# Altium schematic sheet LIDO-HW.100.RearConnector.SchDoc(LIDO-HW.100.RearConnector)
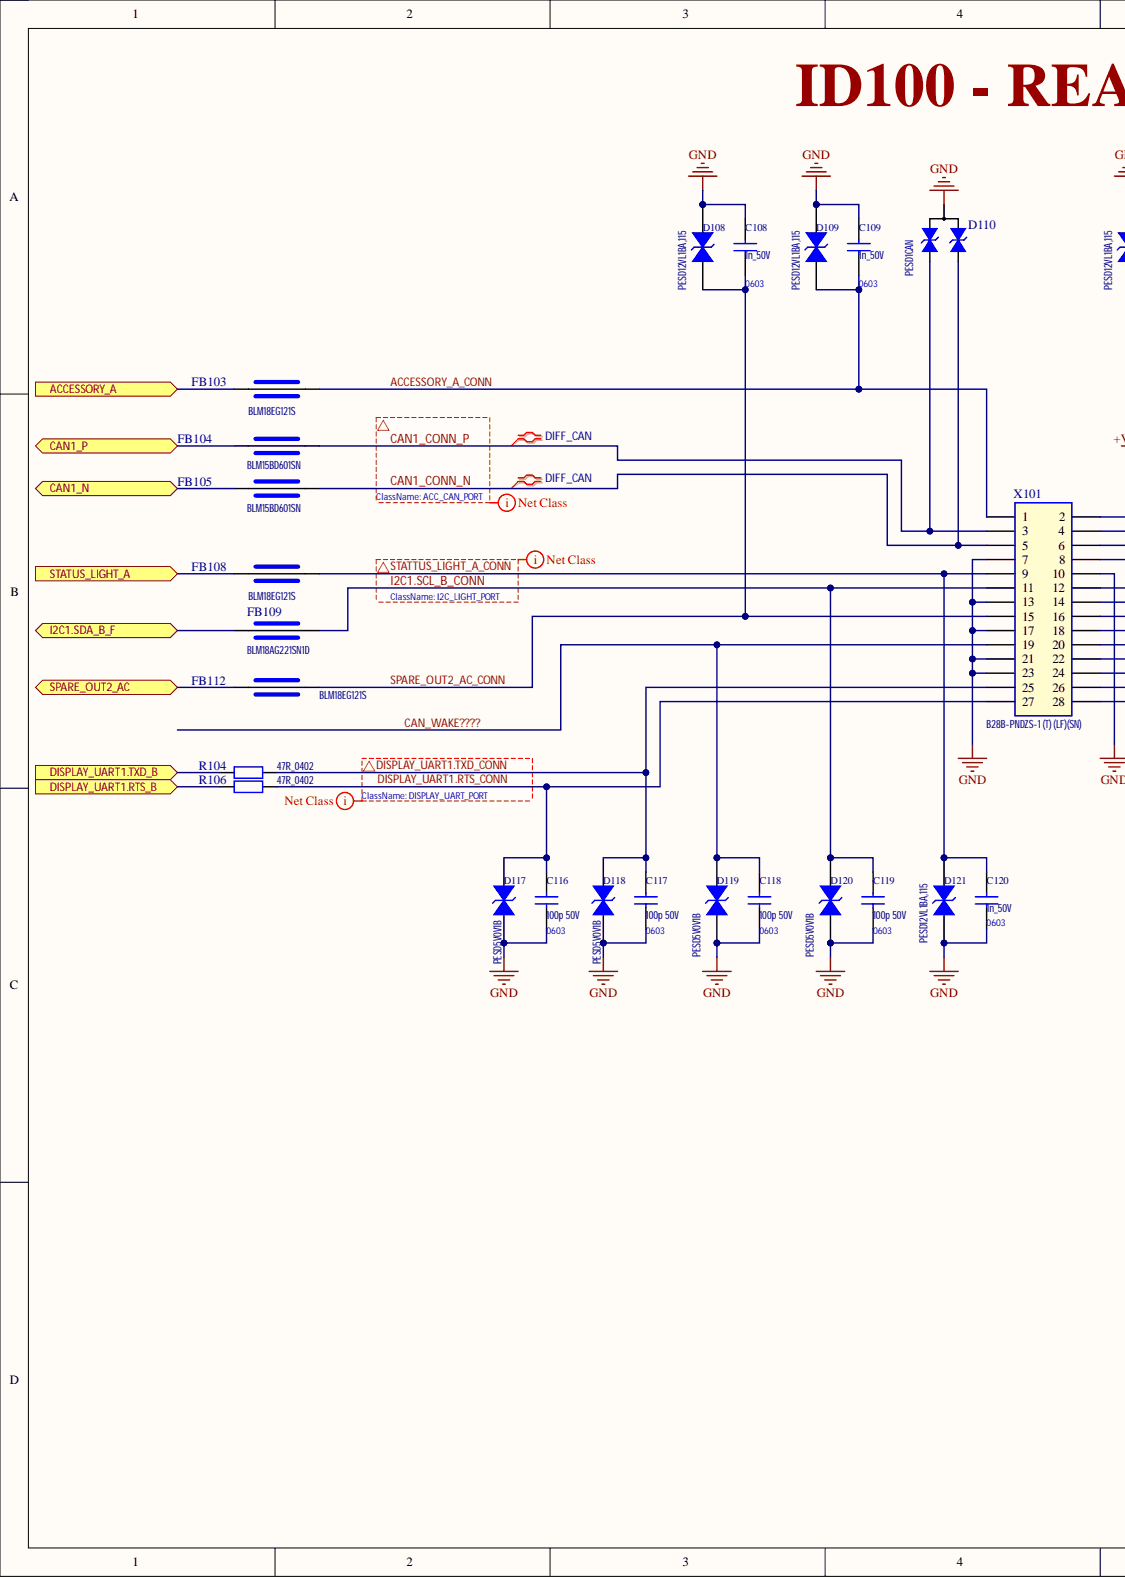
[diagram p0: LIDO-HW.100.RearConnector.SchDoc, left]
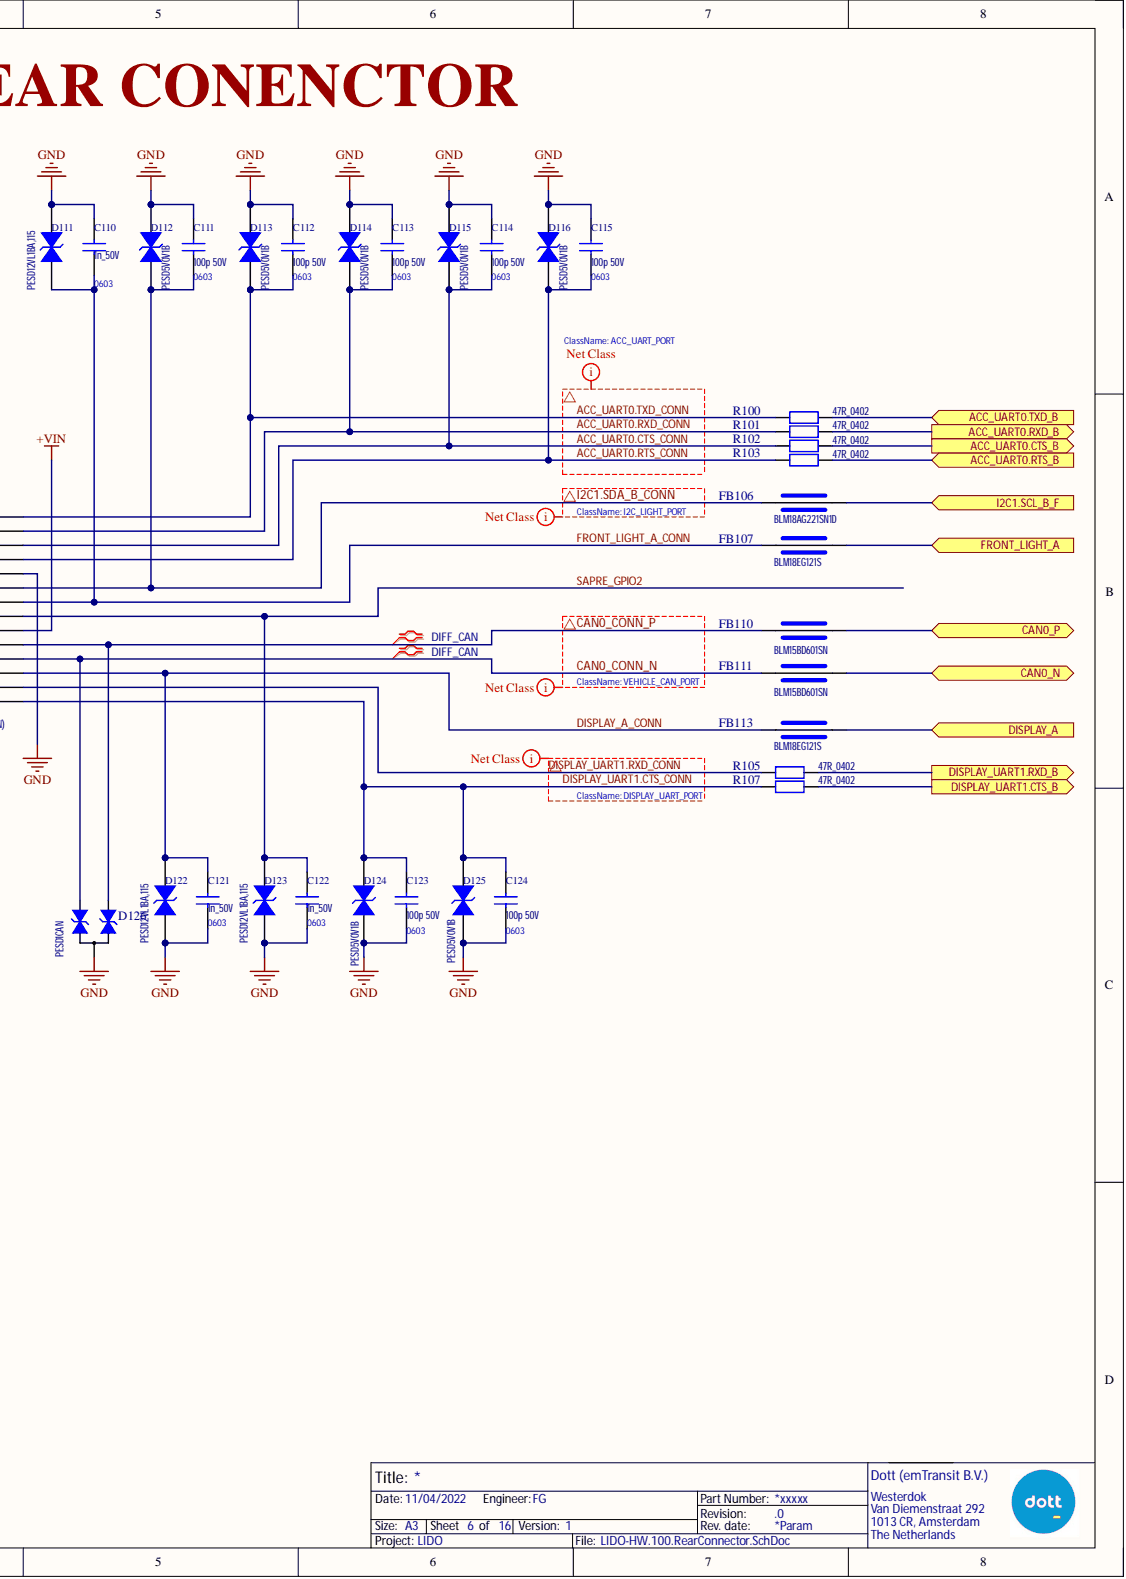
[diagram p1: LIDO-HW.100.RearConnector.SchDoc, right]

## Parts
C108  1n_50V
C109  1n_50V
C110  1n_50V
C111  100p 50V
C112  100p 50V
C113  100p 50V
C114  100p 50V
C115  100p 50V
C116  100p 50V
C117  100p 50V
C118  100p 50V
C119  100p 50V
C120  1n_50V
C121  1n_50V
C122  1n_50V
C123  100p 50V
C124  100p 50V
D108  PESD12VL1BA,115
D109  PESD12VL1BA,115
D110  PESD1CAN
D111  PESD12VL1BA,115
D112  PESD5V0V1B
D113  PESD5V0V1B
D114  PESD5V0V1B
D115  PESD5V0V1B
D116  PESD5V0V1B
D117  PESD5V0V1B
D118  PESD5V0V1B
D119  PESD5V0V1B
D120  PESD5V0V1B
D121  PESD12VL1BA,115
D122  PESD12VL1BA,115
D123  PESD12VL1BA,115
D124  PESD5V0V1B
D125  PESD5V0V1B
D126  PESD1CAN
FB103  BLM18EG121S
FB104  BLM15BD601SN
FB105  BLM15BD601SN
FB106  BLM18AG221SN1D
FB107  BLM18EG121S
FB108  BLM18EG121S
FB109  BLM18AG221SN1D
FB110  BLM15BD601SN
FB111  BLM15BD601SN
FB112  BLM18EG121S
FB113  BLM18EG121S
R100  47R_0402
R101  47R_0402
R102  47R_0402
R103  47R_0402
R104  47R_0402
R105  47R_0402
R106  47R_0402
R107  47R_0402
X101  B28B-PNDZS-1 (T) (LF)(SN)

## Ports
ACC_UART0.CTS_B, ACC_UART0.RTS_B, ACC_UART0.RXD_B, ACC_UART0.TXD_B, ACCESSORY_A, CAN0_N, CAN0_P, CAN1_N, CAN1_P, DISPLAY_A, DISPLAY_UART1.CTS_B, DISPLAY_UART1.RTS_B, DISPLAY_UART1.RXD_B, DISPLAY_UART1.TXD_B, FRONT_LIGHT_A, I2C1.SCL_B_F, I2C1.SDA_B_F, SPARE_OUT2_AC, STATUS_LIGHT_A

## Nets
+VIN: X101.18
GND: C108.2 C109.2 C110.2 C111.1 C112.1 C113.1 C114.1 C115.1 C116.1 C117.1 C118.1 C119.1 C120.1 C121.1 C122.1 C123.1 C124.1 D108.C D109.C D110.3 D111.C D112.A D113.A D114.A D115.A D116.A D117.A D118.A D119.A D120.A D121.A D122.A D123.A D124.A D125.A D126.3 X101.7 X101.10 X101.13 X101.17 X101.21 X101.23
ACC_UART0.CTS_B: R102.2 ; port ACC_UART0.CTS_B
ACC_UART0.CTS_CONN: C114.2 D115.C R102.1 X101.6
ACC_UART0.RTS_B: R103.2 ; port ACC_UART0.RTS_B
ACC_UART0.RTS_CONN: C115.2 D116.C R103.1 X101.8
ACC_UART0.RXD_B: R101.2 ; port ACC_UART0.RXD_B
ACC_UART0.RXD_CONN: C113.2 D114.C R101.1 X101.4
ACC_UART0.TXD_B: R100.2 ; port ACC_UART0.TXD_B
ACC_UART0.TXD_CONN: C112.2 D113.C R100.1 X101.2
ACCESSORY_A: FB103.1 ; port ACCESSORY_A
ACCESSORY_A_CONN: C109.1 D109.A FB103.2 X101.1
CAN0_CONN_N: D126.2 FB111.2 X101.22
CAN0_CONN_P: D126.1 FB110.2 X101.20
CAN0_N: FB111.1 ; port CAN0_N
CAN0_P: FB110.1 ; port CAN0_P
CAN1_CONN_N: D110.1 FB105.2 X101.5
CAN1_CONN_P: D110.2 FB104.2 X101.3
CAN1_N: FB105.1 ; port CAN1_N
CAN1_P: FB104.1 ; port CAN1_P
CAN_WAKE????: C118.2 D119.C X101.19
DISPLAY_A: FB113.1 ; port DISPLAY_A
DISPLAY_A_CONN: C121.2 D122.C FB113.2 X101.24
DISPLAY_UART1.CTS_B: R107.2 ; port DISPLAY_UART1.CTS_B
DISPLAY_UART1.CTS_CONN: C123.2 C124.2 D124.C D125.C R107.1 X101.28
DISPLAY_UART1.RTS_B: R106.2 ; port DISPLAY_UART1.RTS_B
DISPLAY_UART1.RTS_CONN: C116.2 D117.C R106.1 X101.27
DISPLAY_UART1.RXD_B: R105.2 ; port DISPLAY_UART1.RXD_B
DISPLAY_UART1.RXD_CONN: R105.1 X101.26
DISPLAY_UART1.TXD_B: R104.2 ; port DISPLAY_UART1.TXD_B
DISPLAY_UART1.TXD_CONN: C117.2 D118.C R104.1 X101.25
FRONT_LIGHT_A: FB107.1 ; port FRONT_LIGHT_A
FRONT_LIGHT_A_CONN: C110.1 D111.A FB107.2 X101.14
I2C1.SCL_B_CONN: C119.2 D120.C FB109.2 X101.11
I2C1.SCL_B_F: FB106.1 ; port I2C1.SCL_B_F
I2C1.SDA_B_CONN: C111.2 D112.C FB106.2 X101.12
I2C1.SDA_B_F: FB109.1 ; port I2C1.SDA_B_F
SAPRE_GPIO2: C122.2 D123.C X101.16
SPARE_OUT2_AC: FB112.1 ; port SPARE_OUT2_AC
SPARE_OUT2_AC_CONN: C108.1 D108.A FB112.2 X101.15
STATTUS_LIGHT_A_CONN: C120.2 D121.C FB108.2 X101.9
STATUS_LIGHT_A: FB108.1 ; port STATUS_LIGHT_A

## Library data of the parts
C108-C124 = Ceramic: Ceramic CAP Symbol; Component Kind: Standard; Library Name: 01_Capacitors.SchLib
D108 D109 D111-D125 = BI-TVS_DIODE_B: Bidirectional TVS Diode Symbol; Component Kind: Standard; Library Name: 04_Circuit_Protection.SchLib
D110 D126 = Double Bi-TVS_B: Double bidirectional ESD protection diodes; Component Kind: Standard; Library Name: 04_Circuit_Protection.SchLib
FB103-FB113 = FERRITE_BEAD: Ferrite Bead Symbol; Component Kind: Standard; Library Name: 08_Filters.SchLib
R100-R107 = Resistor: Resistor Symbol; Component Kind: Standard; Library Name: 02_Resistors.SchLib
X101 = RECT_28PIN_2R_A: Rectengular connector, 24 pins, 2 row, Version A; Component Kind: Standard; Library Name: 20_Connectors_Rectangular.SchLib
